# Altium schematic sheet IO MAPPING - Pin Map.SchDoc
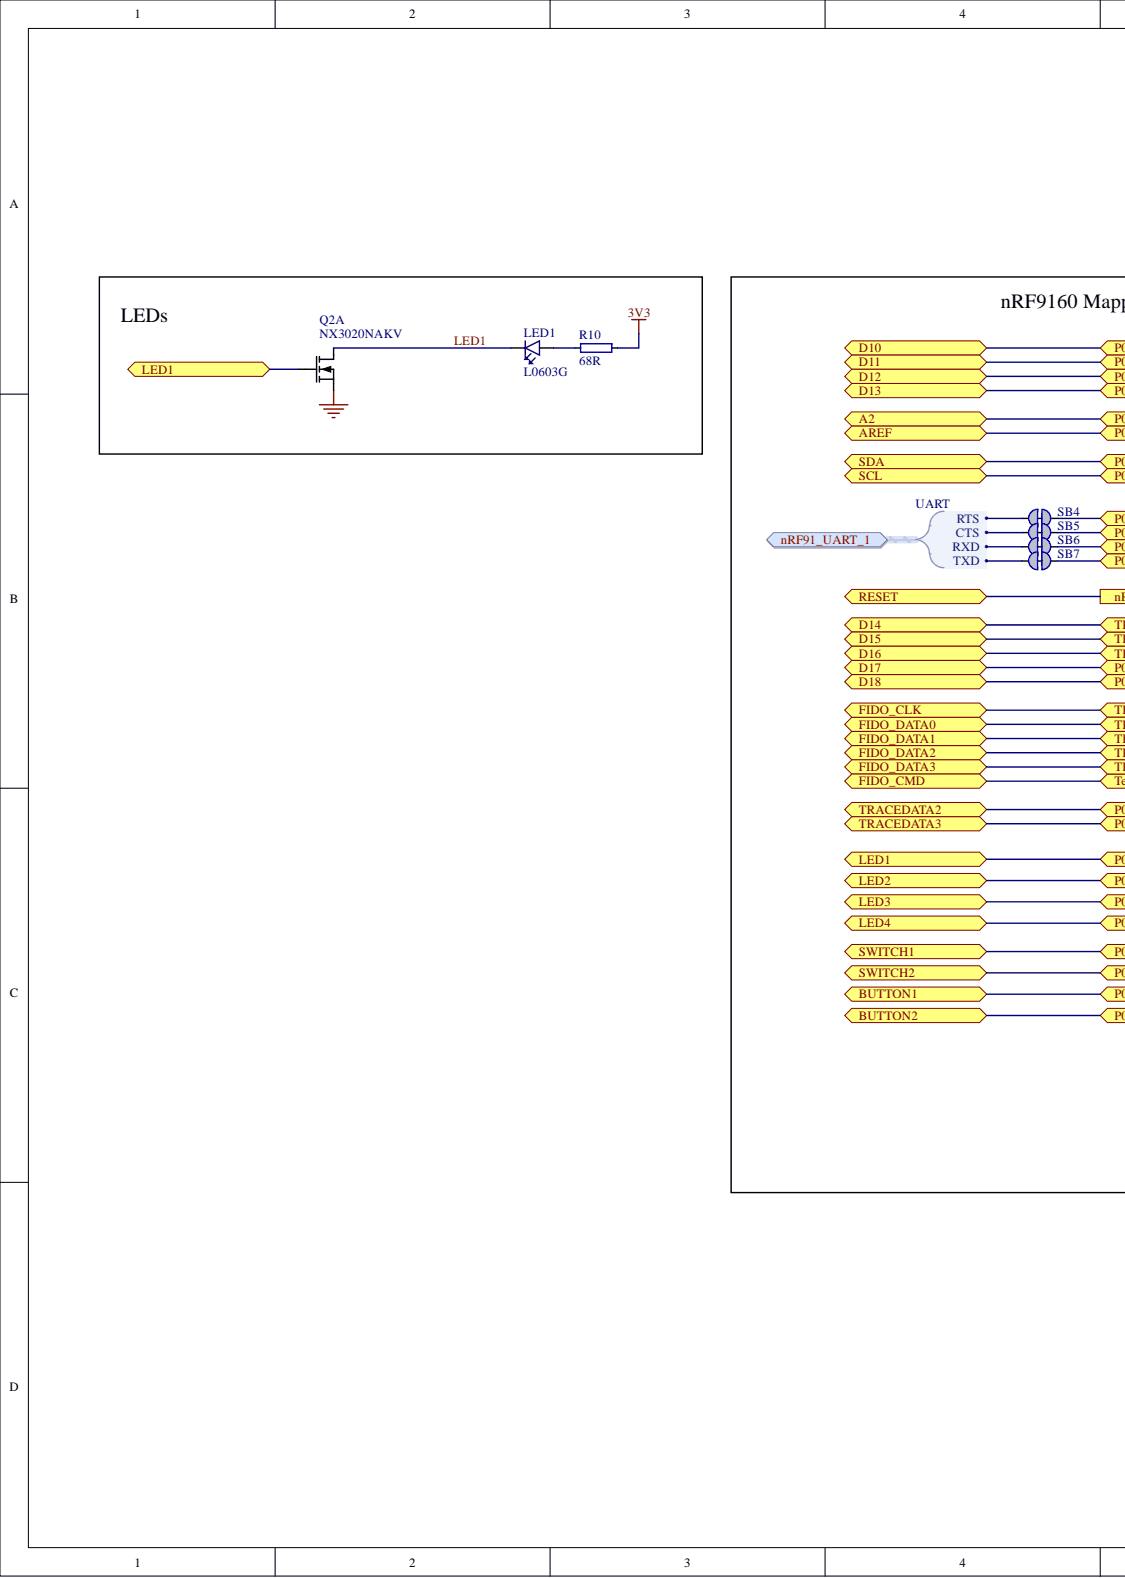
[diagram p0: IO MAPPING - Pin Map.SchDoc, left]
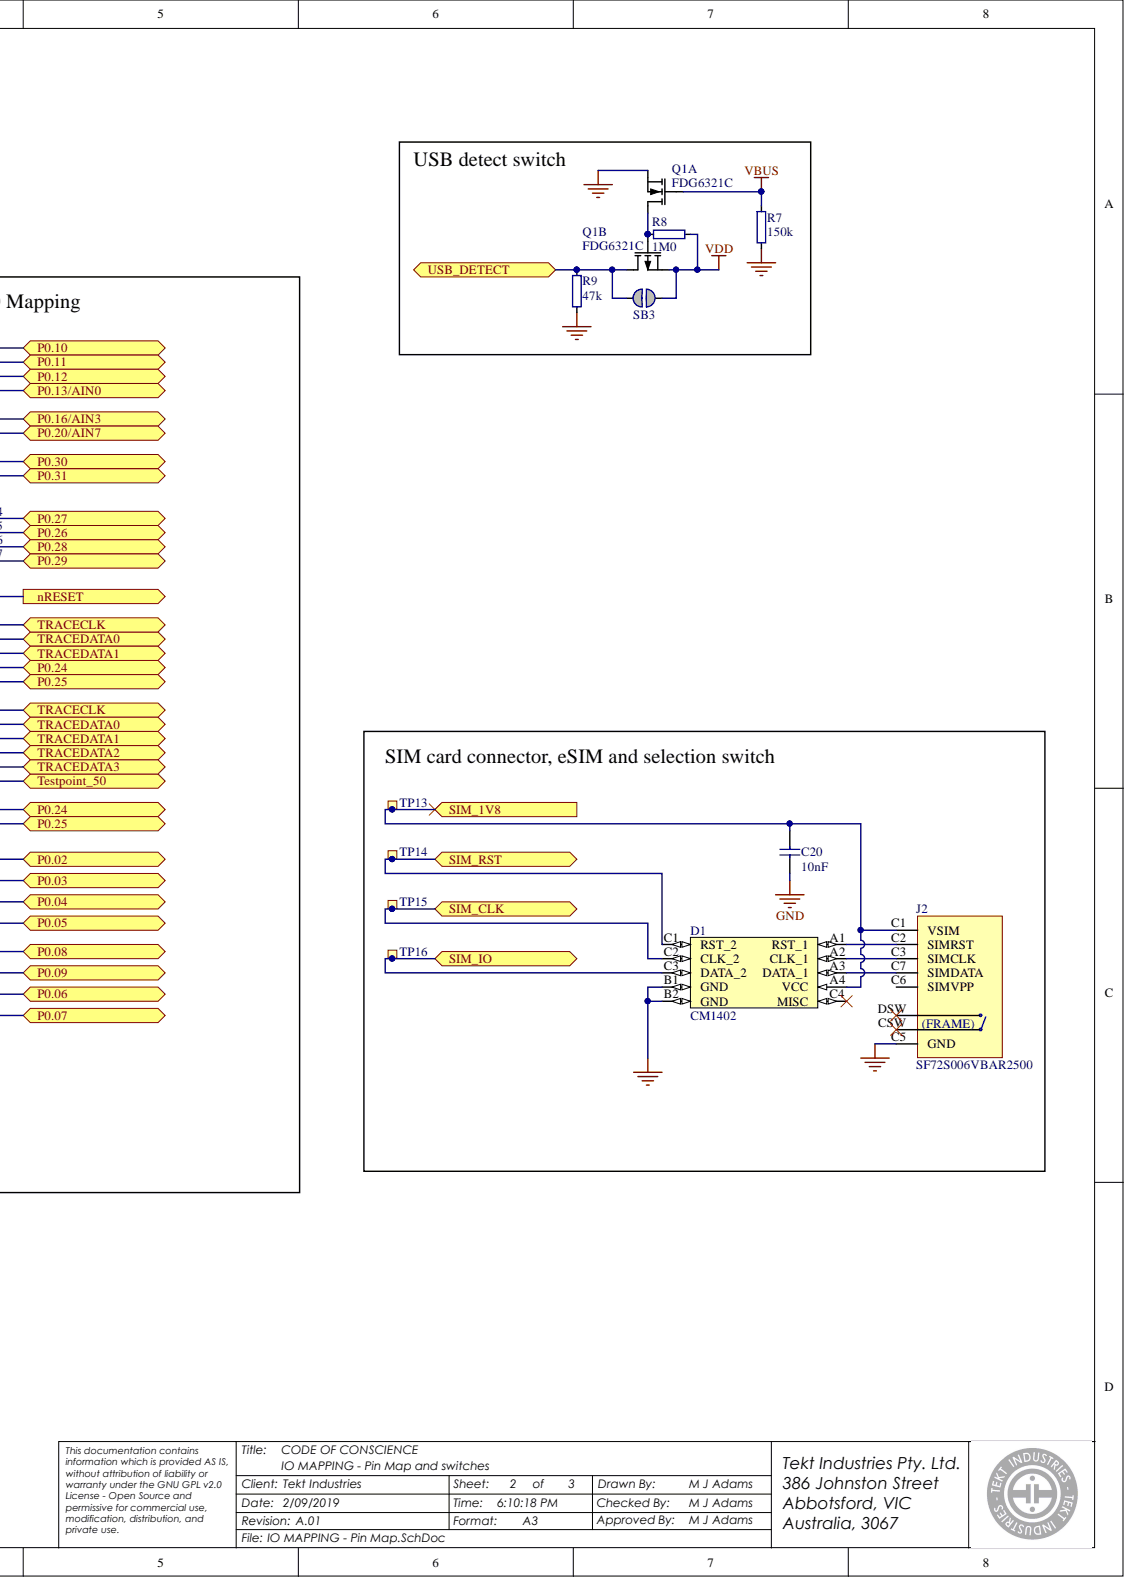
[diagram p1: IO MAPPING - Pin Map.SchDoc, right]

## Parts
C20  10nF  [CAPC0603X03L_C]
D1  CM1402  [CM1402]
J2  SF72S006VBAR2500  [SF72S006VBAR2500]
LED1  L0603G  [LED_0603_G]
Q1  FDG6321C  [SOT65P210X100-6N]  (parts A,B)
Q2  NX3020NAKV  [NX3020NAKV]  (part A)
R7  150k  [RESC0603X03L_C]
R8  1M0  [RESC0603X03L_C]
R9  47k  [RESC0603X03L_C]
R10  68R  [RESC0603X03L_C]
SB3  Solderbridge  [Solderbridge]  (value not drawn)  (no BOM)
SB4  Solderbridge  [Solderbridge_Shorted]  (value not drawn)
SB5  Solderbridge  [Solderbridge_Shorted]  (value not drawn)
SB6  Solderbridge  [Solderbridge_Shorted]  (value not drawn)
SB7  Solderbridge  [Solderbridge_Shorted]  (value not drawn)
TP13  Ø1.5mm,SMD  [TP_SMD_Ø1.5mm]  (value not drawn)  (no BOM)
TP14  Ø1.5mm,SMD  [TP_SMD_Ø1.5mm]  (value not drawn)  (no BOM)
TP15  Ø1.5mm,SMD  [TP_SMD_Ø1.5mm]  (value not drawn)  (no BOM)
TP16  Ø1.5mm,SMD  [TP_SMD_Ø1.5mm]  (value not drawn)  (no BOM)

## Ports
A2, AREF, BUTTON1, BUTTON2, D10, D11, D12, D13, D14, D15, D16, D17, D18, FIDO_CLK, FIDO_CMD, FIDO_DATA0, FIDO_DATA1, FIDO_DATA2, FIDO_DATA3, LED1, LED2, LED3, LED4, nRESET, nRF91_UART_1, nRF91_UART_1.CTS, nRF91_UART_1.RTS, nRF91_UART_1.RXD, nRF91_UART_1.TXD, P0.02, P0.03, P0.04, P0.05, P0.06, P0.07, P0.08, P0.09, P0.10, P0.11, P0.12, P0.13/AIN0, P0.16/AIN3, P0.20/AIN7, P0.24, P0.25, P0.26, P0.27, P0.28, P0.29, P0.30, P0.31, RESET, SCL, SDA, SIM_1V8, SIM_CLK, SIM_IO, SIM_RST, SWITCH1, SWITCH2, Testpoint_50, TRACECLK, TRACEDATA0, TRACEDATA1, TRACEDATA2, TRACEDATA3, USB_DETECT

## Nets
3V3: R10.2
GND: C20.2 D1.B1(GND) D1.B2(GND) J2.0 J2.C5(GND) Q1.1 Q2.1 R7.1 R9.1
VBUS: Q1.2 R7.2
VDD: Q1.4 R8.1 SB3.1
LED1: LED1.1 Q2.6
P0.26: SB5.1 ; port P0.26
P0.27: SB4.1 ; port P0.27
P0.28: SB6.1 ; port P0.28
P0.29: SB7.1 ; port P0.29
SIM_1V8: C20.1 D1.A4(VCC) J2.C1(VSIM) TP13.1 ; port SIM_1V8
SIM_CLK: D1.C2(CLK_2) TP15.1 ; port SIM_CLK
SIM_IO: D1.C3(DATA_2) TP16.1 ; port SIM_IO
SIM_RST: D1.C1(RST_2) TP14.1 ; port SIM_RST
USB_DETECT: Q1.3 R9.2 SB3.2 ; port USB_DETECT
NetD1_A1: D1.A1(RST_1) J2.C2(SIMRST)
NetD1_A2: D1.A2(CLK_1) J2.C3(SIMCLK)
NetD1_A3: D1.A3(DATA_1) J2.C7(SIMDATA)
NetLED1_2: LED1.2 R10.1
NetQ1_5: Q1.5 Q1.6 R8.2
NetQ2_2: Q2.2 ; port LED1, port P0.02
NetSB4_2: SB4.2 ; port nRF91_UART_1
NetSB5_2: SB5.2 ; port nRF91_UART_1
NetSB6_2: SB6.2 ; port nRF91_UART_1
NetSB7_2: SB7.2 ; port nRF91_UART_1
N00122: ; port D17, port FIDO_DATA2, port P0.24, port TRACEDATA2
N00123: ; port D18, port FIDO_DATA3, port P0.25, port TRACEDATA3
N00124: ; port FIDO_CMD, port Testpoint_50
N00125: ; port D14, port FIDO_CLK, port TRACECLK
N00126: ; port D16, port FIDO_DATA1, port TRACEDATA1
N00127: ; port D15, port FIDO_DATA0, port TRACEDATA0
N00128: ; port RESET, port nRESET
N00129: ; port P0.31, port SCL
N00130: ; port P0.30, port SDA
N00131: ; port AREF, port P0.20/AIN7
N00132: ; port A2, port P0.16/AIN3
N00133: ; port D13, port P0.13/AIN0
N00134: ; port D12, port P0.12
N00135: ; port D11, port P0.11
N00136: ; port D10, port P0.10
N00137: ; port BUTTON2, port P0.07
N00138: ; port BUTTON1, port P0.06
N00139: ; port P0.09, port SWITCH2
N00140: ; port P0.08, port SWITCH1
N00141: ; port LED2, port P0.03
N00143: ; port LED4, port P0.05
N00144: ; port LED3, port P0.04

Not connected: D1 C4(MISC); J2 C6(SIMVPP) CSW((FRAME)) DSW

## Library data of the parts
C20 = C0201-10n: Capacitor, X7R, ±10%; Manufacturer: Murata Electronics North America; Manufacturer Part No.: GRM033R61E103KA12D; Part number IPS: 00000003480; Artikkelnr Noca: N.A.; Database Table Name: Capacitors$; Note: 10V; Component Kind: Standard; ComponentLink1Description: Datasheet; ComponentLink2Description: Supplier link; Footprint Doc: 0201; LatestRevisionDate: 2017-11-09; Library Name: Nordic Passive DB.DbLib; Part Number: C0201-10n; Pin Count: 2; Published: 2014-11-13; Supplier: Digi-Key; Supplier Part No.: 490-7194-1-ND; Value: 10nF; Publisher: Nordic Semiconductor
D1 = CM1402: EMI Network Filter Arrays SIM CARD EMI FILTER WITH ESD PROTECTION; Manufacturer: ON Semiconductor; Manufacturer Part No.: CM1402-03CP; Artikkelnr Noca: N.A.; Database Table Name: Semiconductors$; Component Kind: Standard; ComponentLink1Description: Datasheet; ComponentLink2Description: Supplier link; Footprint Doc: CM1402; HelpURL: http://www.onsemi.com/pub_link/Collateral/CM1402-D.PDF; LatestRevisionDate: 2017-02-06; Library Name: Nordic Discrete DB.DbLib; Part Number: CM1402; Part number IPS: N.A.; Pin Count: 10; Published: 2017-02-06; Supplier: Digi-Key; Supplier 2: Mouser; Supplier Part No.: CM1402-03CPOSTR-ND; Supplier Part Number 2: 748-CM1402-03CP; Value: CM1402; Publisher: Nordic Semiconductor
J2 = SF72S006VBAR2500: n 8 (6 + 2) Position Card Connector NANO SIM Surface Mount, Right Angle Gold; Manufacturer: JAE; Artikkelnr Noca: N.A.; Database Table Name: Connectors$; Component Kind: Standard; ComponentLink1Description: Datasheet; ComponentLink2Description: Supplier link; Footprint Doc: SF72S006VBAR2500; HelpURL: http://jae-connectors.com/en/pdf/MB-0282-1E_SF72.pdf; LatestRevisionDate: 2016-09-09; Library Name: Nordic Passive DB.DbLib; Manufacturer Part No.: SF72S006VBAR2500; Part Number: SF72S006VBAR2500; Part number IPS: N.A.; Pin Count: 8; Published: 2016-09-09; Supplier: Digi-Key; Supplier 2: Mouser; Supplier Part No.: 670-2799-1-ND; Supplier Part Number 2: 656-SF72S006VBAR2500; Value: SF72S006VBAR2500; Publisher: Nordic Semiconductor
LED1 = LG L29K Smartled Green: LED, Green, 0603, 570nm, Vf=1.7V, 2.24..5.6mcd, - 40 to +100°C; Manufacturer: Osram; Manufacturer Part No.: LG L29K-G2J1-24-Z; Part number IPS: N.A.; Artikkelnr Noca: 13019147; Database Table Name: Optoelectronics$; Note: 2.24..5.6 mcd, Vfmin=1.7V; Component Kind: Standard; ComponentLink1Description: Datasheet; ComponentLink2Description: Supplier link; Footprint Doc: 0603; HelpURL: http://www.osram-os.com/Graphics/XPic2/00077107_0.pdf; LatestRevisionDate: 2017-08-21; Library Name: Nordic Discrete DB.DbLib; Part Number: LG L29K Smartled Green; Pin Count: 2; Published: 2014-10-28; Supplier: Digi-Key; Supplier 2: Mouser; Supplier Part No.: 475-2709-1-ND; Supplier Part Number 2: 720-LGL29KG2J124Z; Value: L0603G; Publisher: Nordic Semiconductor
Q1 = FDG6321C: Fairchild, FDG6321C, Dual N & P Channel Digital FET; Part number IPS: N.A.; Artikkelnr Noca: 35029089; Database Table Name: Semiconductors$; Component Kind: Standard; ComponentLink1Description: Datasheet; ComponentLink2Description: Supplier link; Footprint Doc: SC70-6; HelpURL: http://www.fairchildsemi.com/ds/FD/FDG6321C.pdf; LatestRevisionDate: 2011-05-11; Library Name: Nordic Discrete DB.DbLib; Manufacturer: Fairchild; Manufacturer Part No.: FDG6321C; Note: Dual N & P Channel Digital FET; Part Number: FDG6321C; Pin Count: 6; Published: 2009-09-16; Supplier: Digi-Key; Supplier 2: Digi-Key; Supplier Part No.: FDG6321CCT-ND; Supplier Part Number 2: FDG6321CCT-ND; Value: FDG6321C; Publisher: Nordic Semiconductor
Q2 = NX3020NAKV: MOSFET 2N-CH 30V 0.2A SOT666; Manufacturer: Nexperia; Manufacturer Part No.: NX3020NAKV,115; Artikkelnr Noca: N.A.; Database Table Name: Semiconductors$; Component Kind: Standard; ComponentLink1Description: Datasheet; ComponentLink1URL: https://assets.nexperia.com/documents/data-sheet/NX3020NAKV.pdf; ComponentLink2Description: Supplier link; Footprint Doc: SOT666; LatestRevisionDate: 2018-12-13; Library Name: Nordic Discrete DB.DbLib; Part Number: NX3020NAKV; Part number IPS: N.A.; Pin Count: 6; Published: 2018-12-13; Supplier: Digi-Key; Supplier 2: Mouser; Supplier Part No.: 1727-1287-2-ND; Supplier Part Number 2: 771-NX3020NAKV115; Value: NX3020NAKV; Publisher: Nordic Semiconductor
R7 = R0201-150k: Resistor, ±1%, 0.05W; Manufacturer: Stackpole Electronics Inc; Manufacturer Part No.: RMCF0201FT150K; Artikkelnr Noca: N.A.; Database Table Name: Resistors$; Note: Chip Res 1%; Component Kind: Standard; ComponentLink1Description: Datasheet; ComponentLink2Description: Supplier link; Footprint Doc: 0201; LatestRevisionDate: 2014-11-13; Library Name: Nordic Passive DB.DbLib; Part Number: R0201-150k; Part number IPS: N.A.; Pin Count: 2; Published: 2014-11-13; Supplier: Digi-Key; Supplier Part No.: RMCF0201FT150KCT-ND; Value: 150k; Publisher: Nordic Semiconductor
R8 = R0201-1M0: Resistor, ±1%, 0.05W; Manufacturer: Yageo; Manufacturer Part No.: RC0201FR-071ML; Artikkelnr Noca: N.A.; Database Table Name: Resistors$; Note: Chip Res 1%; Component Kind: Standard; ComponentLink1Description: Datasheet; ComponentLink2Description: Supplier link; Footprint Doc: 0201; LatestRevisionDate: 2014-11-13; Library Name: Nordic Passive DB.DbLib; Part Number: R0201-1M0; Part number IPS: N.A.; Pin Count: 2; Published: 2014-11-13; Supplier: Digi-Key; Supplier Part No.: 311-1MMCT-ND; Value: 1M0; Publisher: Nordic Semiconductor
R9 = R0201-47k: Resistor, ±1%, 0.05W; Manufacturer: Samsung Electro-Mechanics; Manufacturer Part No.: RC0603F473CS; Artikkelnr Noca: N.A.; Database Table Name: Resistors$; Note: Chip Res 1%; Component Kind: Standard; ComponentLink1Description: Datasheet; ComponentLink2Description: Supplier link; Footprint Doc: 0201; LatestRevisionDate: 2014-11-13; Library Name: Nordic Passive DB.DbLib; Part Number: R0201-47k; Part number IPS: N.A.; Pin Count: 2; Published: 2014-11-13; Supplier: Digi-Key; Supplier Part No.: 1276-3701-1-ND; Value: 47k; Publisher: Nordic Semiconductor
R10 = R0201-68R: Resistor, ±1%, 0.05W; Manufacturer: Yageo; Manufacturer Part No.: RC0201FR-0768RL; Artikkelnr Noca: N.A.; Database Table Name: Resistors$; Note: Chip Res 1%; Component Kind: Standard; ComponentLink1Description: Datasheet; ComponentLink2Description: Supplier link; Footprint Doc: 0201; LatestRevisionDate: 2014-11-13; Library Name: Nordic Passive DB.DbLib; Part Number: R0201-68R; Part number IPS: N.A.; Pin Count: 2; Published: 2014-11-13; Supplier: Digi-Key; Supplier Part No.: YAG2773CT-ND; Value: 68R; Publisher: Nordic Semiconductor
SB3 = Solderbridge: Artikkelnr Noca: N.A.; Database Table Name: Connectors$; ComponentLink1Description: Datasheet; ComponentLink2Description: Supplier link; Description: Solderbridge; Footprint Doc: Solderbridge; LatestRevisionDate: 2009-12-14; Library Name: Nordic Passive DB.DbLib; Part Number: Solderbridge; Part number IPS: N.A.; Pin Count: 2; Published: 2009-12-14; Value: Solderbridge; Publisher: Nordic Semiconductor
SB4-SB7 = Solderbridge Shorted: Shorted Solderbridge; Artikkelnr Noca: N.A.; Database Table Name: Connectors$; Component Kind: Net Tie; ComponentLink1Description: Datasheet; ComponentLink2Description: Supplier link; Footprint Doc: Solderbridge_Shorted; LatestRevisionDate: 2012-08-07; Library Name: Nordic Passive DB.DbLib; Part Number: Solderbridge Shorted; Part number IPS: N.A.; Pin Count: 2; Published: 2012-08-07; Value: Solderbridge; Publisher: Nordic Semiconductor
TP13-TP16 = TP_SMD_Ø1.5MM: 1.0mm circular SMD testpad; Artikkelnr Noca: N.A.; Database Table Name: Connectors$; ComponentLink1Description: Datasheet; ComponentLink2Description: Supplier link; Footprint Doc: TP_SMD_Ø1.5mm; LatestRevisionDate: 2016-06-02; Library Name: Nordic Passive DB.DbLib; Part Number: TP_SMD_Ø1.5MM; Part number IPS: N.A.; Pin Count: 1; Published: 2016-06-02; Value: Ø1.5mm,SMD; Publisher: Nordic Semiconductor
